# Altium schematic sheet AES-MS-MT3620-SK-G_FTDI.SchDoc(AES-MS-MT3620-SK-G_FTDI)
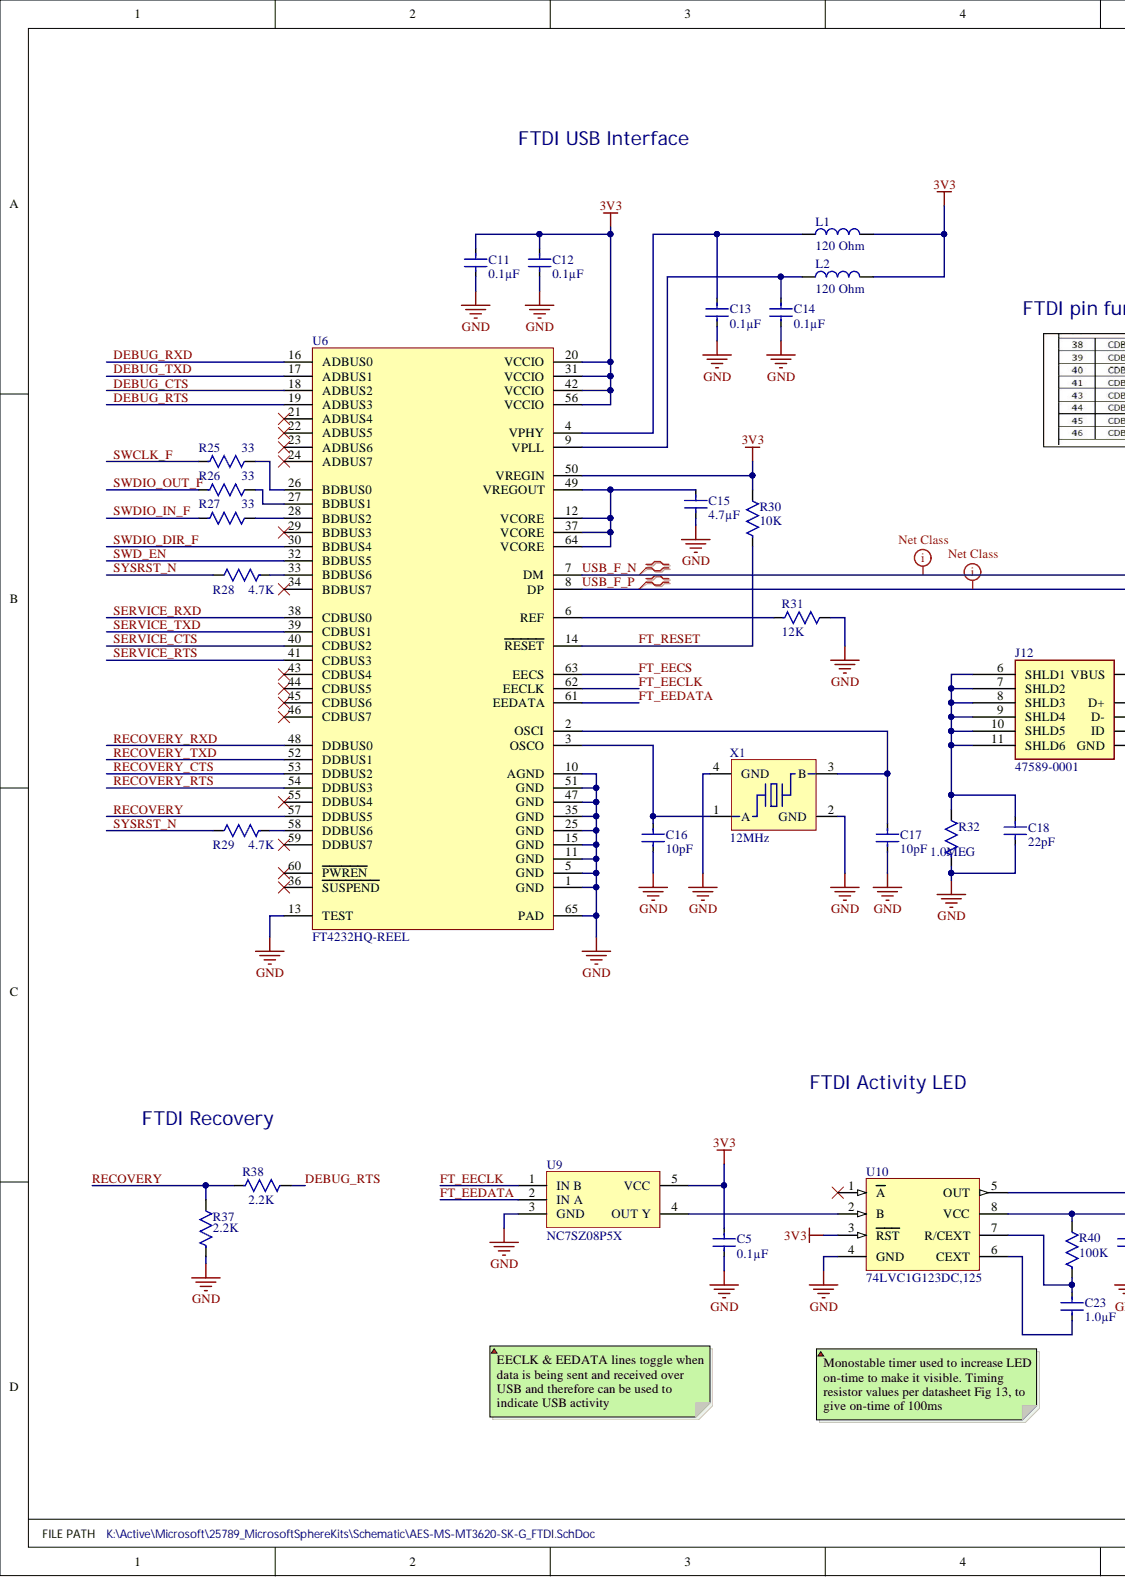
[diagram p0: AES-MS-MT3620-SK-G_FTDI.SchDoc, left]
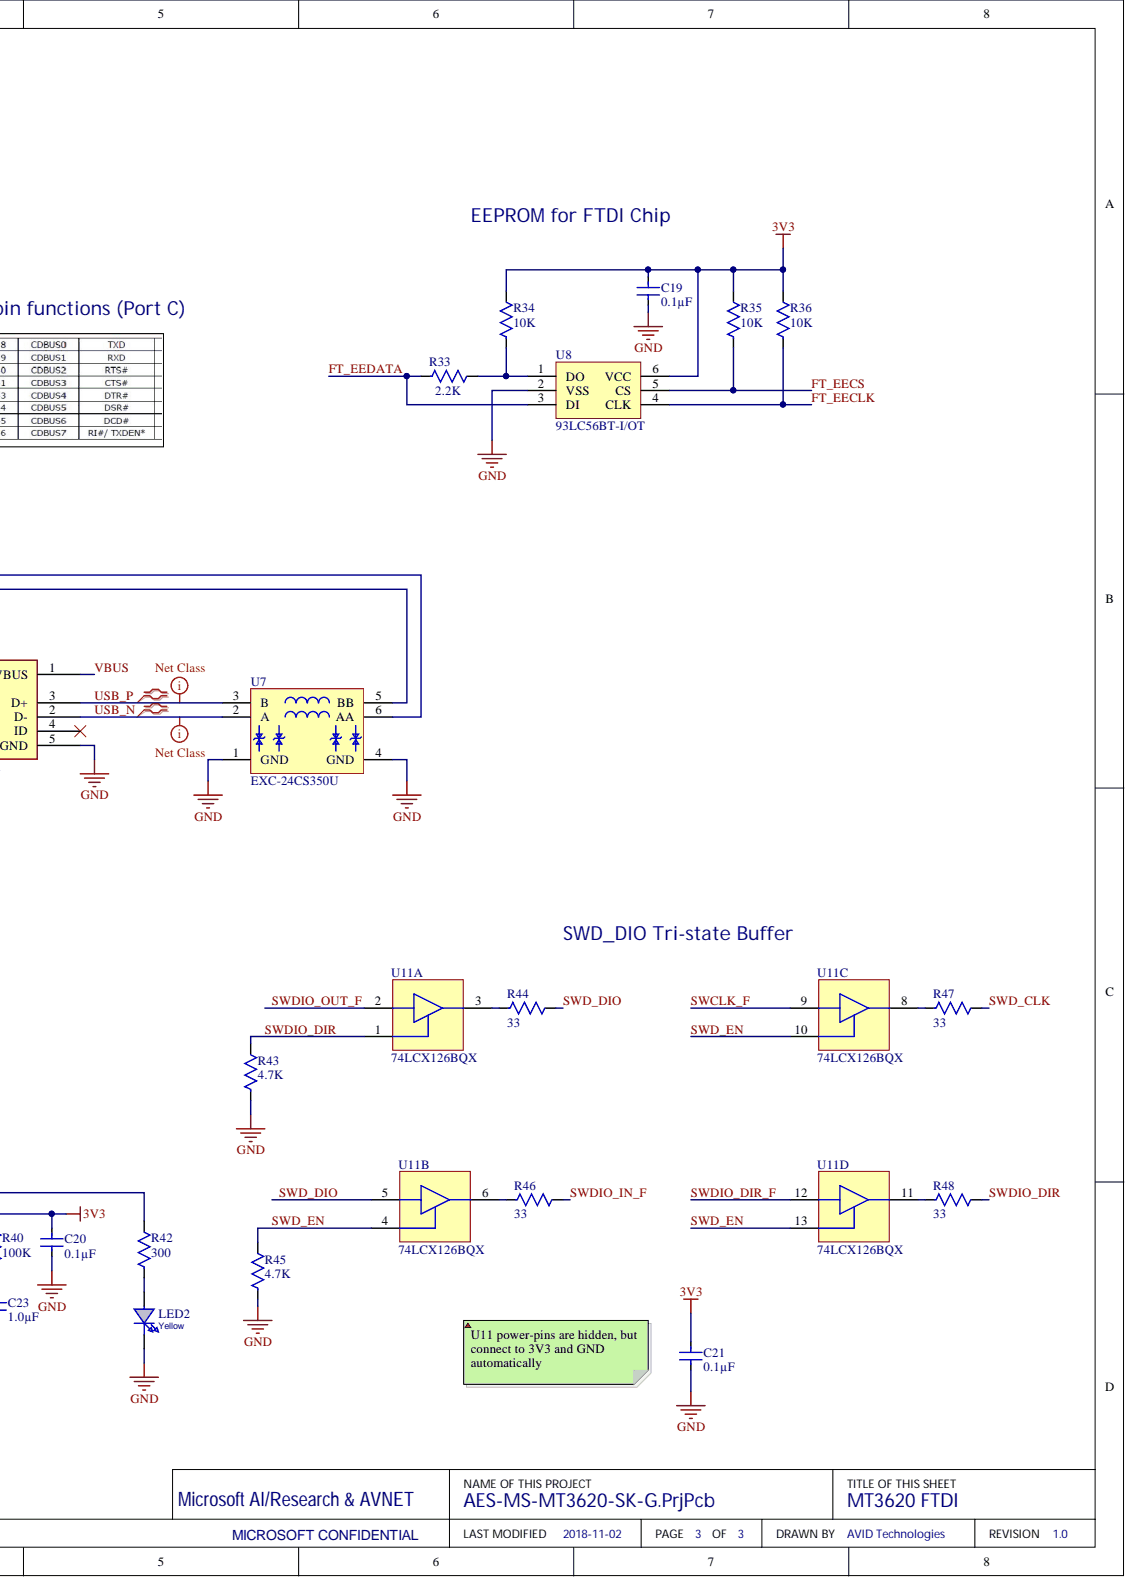
[diagram p1: AES-MS-MT3620-SK-G_FTDI.SchDoc, right]

## Parts
C5  Capacitance: 0.1µF  [CAPC1005-EIA0402 0.55MM MED]  (hidden comment: 0.1uF)
C11  Capacitance: 0.1µF  [CAPC1005-EIA0402 0.55MM MED]  (hidden comment: 0.1uF)
C12  Capacitance: 0.1µF  [CAPC1005-EIA0402 0.55MM MED]  (hidden comment: 0.1uF)
C13  Capacitance: 0.1µF  [CAPC1005-EIA0402 0.55MM MED]  (hidden comment: 0.1uF)
C14  Capacitance: 0.1µF  [CAPC1005-EIA0402 0.55MM MED]  (hidden comment: 0.1uF)
C15  Capacitance: 4.7µF  [CAPC1005-EIA0402 0.55MM MED]  (hidden comment: 4.7uF)
C16  10pF  [CAPC1005-EIA0402 0.55MM MED]
C17  10pF  [CAPC1005-EIA0402 0.55MM MED]
C18  22pF  [CAPC1005-EIA0402 0.55MM MED]
C19  Capacitance: 0.1µF  [CAPC1005-EIA0402 0.55MM MED]  (hidden comment: 0.1uF)
C20  Capacitance: 0.1µF  [CAPC1005-EIA0402 0.55MM MED]  (hidden comment: 0.1uF)
C21  Capacitance: 0.1µF  [CAPC1005-EIA0402 0.55MM MED]  (hidden comment: 0.1uF)
C23  1.0µF  [CAPC1005-EIA0402 0.55MM MED]
J12  47589-0001  [47589-0001]
L1  120 Ohm  [CAPC1005-EIA0402 0.55MM MED]
L2  120 Ohm  [CAPC1005-EIA0402 0.55MM MED]
LED2  Yellow
R25  33  [RES 0402 MEDIUM DENSITY]
R26  33  [RES 0402 MEDIUM DENSITY]
R27  33  [RES 0402 MEDIUM DENSITY]
R28  4.7K  [RES 0402 MEDIUM DENSITY]
R29  4.7K  [RES 0402 MEDIUM DENSITY]
R30  10K  [RES 0402 MEDIUM DENSITY]
R31  12K  [RES 0402 MEDIUM DENSITY]
R32  Resistance (Ohms): 1.0MEG  [RES 0402 MEDIUM DENSITY]  (hidden comment: 1MEG)
R33  2.2K  [RES 0402 MEDIUM DENSITY]
R34  10K  [RES 0402 MEDIUM DENSITY]
R35  10K  [RES 0402 MEDIUM DENSITY]
R36  10K  [RES 0402 MEDIUM DENSITY]
R37  2.2K  [RES 0402 MEDIUM DENSITY]
R38  2.2K  [RES 0402 MEDIUM DENSITY]
R40  100K  [RES 0402 MEDIUM DENSITY]
R42  300  [RES 0402 MEDIUM DENSITY]
R43  4.7K  [RES 0402 MEDIUM DENSITY]
R44  33  [RES 0402 MEDIUM DENSITY]
R45  4.7K  [RES 0402 MEDIUM DENSITY]
R46  33  [RES 0402 MEDIUM DENSITY]
R47  33  [RES 0402 MEDIUM DENSITY]
R48  33  [RES 0402 MEDIUM DENSITY]
U6  Manufacturer Part Number 1: FT4232HQ-REEL  [FTDI_TF4232HQ_64QFN]  (hidden comment: IC_FT4232HQ)
U7  Manufacturer Part Number 1: EXC-24CS350U  [EXC24CS]  (hidden comment: IC_EXC-24CS350U)
U8  Manufacturer Part Number 1: 93LC56BT-I/OT  [SOT23-93C56B]  (hidden comment: IC_93LC56BT-I/OT)
U9  Manufacturer Part Number 1: NC7SZ08P5X  [SC-70-5]  (hidden comment: IC_NC7SZ08P5X)
U10  Manufacturer Part Number 1: 74LVC1G123DC,125  [SOP50P310X100-8N]  (hidden comment: IC_74LVC1G123DC)
U11  IC_74LCX126BQX  [74LCX126BQX]  (value not drawn)  (parts A,B,C,D)
X1  12MHz  [Crystal_FL_NKS3]

## Nets
3V3: C5.2 C11.2 C12.2 C19.2 C20.2 C21.2 L1.2 L2.2 R30.1 R34.1 R35.1 R36.1 R40.1 U6.20(VCCIO) U6.31(VCCIO) U6.42(VCCIO) U6.50(VREGIN) U6.56(VCCIO) U8.6(VCC) U9.5(VCC) U10.3(RST) U10.8(VCC) U11.14
GND: C5.1 C11.1 C12.1 C13.1 C14.1 C15.1 C16.1 C17.1 C18.1 C19.1 C20.1 C21.1 J12.5(GND) LED2.K R31.1 R32.2 R37.2 R43.2 R45.2 U6.1(GND) U6.5(GND) U6.10(AGND) U6.11(GND) U6.13(TEST) U6.15(GND) U6.25(GND) U6.35(GND) U6.47(GND) U6.51(GND) U6.65(PAD) U7.1(GND) U7.4(GND) U8.2(VSS) U9.3(GND) U10.4(GND) U11.7 X1.2(GND) X1.4(GND)
DEBUG_CTS: U6.18(ADBUS2)
DEBUG_RTS: R38.1 U6.19(ADBUS3)
DEBUG_RXD: U6.16(ADBUS0)
DEBUG_TXD: U6.17(ADBUS1)
FT_EECLK: R36.2 U6.62(EECLK) U8.4(CLK) U9.1(IN_B)
FT_EECS: R35.2 U6.63(EECS) U8.5(CS)
FT_EEDATA: R33.2 U6.61(EEDATA) U8.3(DI) U9.2(IN_A)
FT_RESET: R30.2 U6.14(RESET)
RECOVERY: R37.1 R38.2 U6.57(DDBUS5)
RECOVERY_CTS: U6.53(DDBUS2)
RECOVERY_RTS: U6.54(DDBUS3)
RECOVERY_RXD: U6.48(DDBUS0)
RECOVERY_TXD: U6.52(DDBUS1)
SERVICE_CTS: U6.40(CDBUS2)
SERVICE_RTS: U6.41(CDBUS3)
SERVICE_RXD: U6.38(CDBUS0)
SERVICE_TXD: U6.39(CDBUS1)
SWCLK_F: R25.2 U11.9
SWD_CLK: R47.1
SWD_DIO: R44.1 U11.5
SWD_EN: R45.1 U6.32(BDBUS5) U11.4 U11.10 U11.13
SWDIO_DIR: R43.1 R48.1 U11.1
SWDIO_DIR_F: U6.30(BDBUS4) U11.12
SWDIO_IN_F: R27.2 R46.1
SWDIO_OUT_F: R26.2 U11.2
SYSRST_N: R28.1 R29.1
USB_F_N: U6.7(DM) U7.6(AA)
USB_F_P: U6.8(DP) U7.5(BB)
USB_N: J12.2(D-) U7.2(A)
USB_P: J12.3(D+) U7.3(B)
VBUS: J12.1(VBUS)
NetC13_2: C13.2 L1.1 U6.4(VPHY)
NetC14_2: C14.2 L2.1 U6.9(VPLL)
NetC15_2: C15.2 U6.12(VCORE) U6.37(VCORE) U6.49(VREGOUT) U6.64(VCORE)
NetC16_2: C16.2 U6.3(OSCO) X1.1(A)
NetC17_2: C17.2 U6.2(OSCI) X1.3(B)
NetC18_2: C18.2 J12.6(SHLD1) J12.7(SHLD2) J12.8(SHLD3) J12.9(SHLD4) J12.10(SHLD5) J12.11(SHLD6) R32.1
NetC23_1: C23.1 U10.6(CEXT)
NetC23_2: C23.2 R40.2 U10.7(R/CEXT)
NetLED2_A: LED2.A R42.2
NetR25_1: R25.1 U6.26(BDBUS0)
NetR26_1: R26.1 U6.27(BDBUS1)
NetR27_1: R27.1 U6.28(BDBUS2)
NetR28_2: R28.2 U6.33(BDBUS6)
NetR29_2: R29.2 U6.58(DDBUS6)
NetR31_2: R31.2 U6.6(REF)
NetR33_1: R33.1 R34.2 U8.1(DO)
NetR42_1: R42.1 U10.5(OUT)
NetR44_2: R44.2 U11.3
NetR46_2: R46.2 U11.6
NetR47_2: R47.2 U11.8
NetR48_2: R48.2 U11.11
NetU9_4: U9.4(OUT_Y) U10.2(B)

Not connected: J12 4(ID); U6 21(ADBUS4) 22(ADBUS5) 23(ADBUS6) 24(ADBUS7) 29(BDBUS3) 34(BDBUS7) 36(SUSPEND) 43(CDBUS4) 44(CDBUS5) 45(CDBUS6) 46(CDBUS7) 55(DDBUS4) 59(DDBUS7) 60(PWREN); U10 1(A)

## Library data of the parts
C5 C11-C14 C19-C21 = 0.1µF 0402 X7R 50V: CAP CER 0.1UF 25V 10% X5R 0402; Manufacturer Part Number 1: CL05A104KA5NNNC; Manufacturer 1: Samsung; Width: 0.02inch; Voltage Rating (DC): 25V; Voltage Rating: 25V; Voltage: 25V; Tolerance: 10%; Thickness: 0.022inch; Supplier Part Number 1: 1276-1043-1-ND; Supplier 1: Digi-Key; Stock 1: 100000; Radiation Hardening: No; Pricing 1: 1=0.1, 10=0.032, 100=0.0141, 500=0.0101, 1000=0.0079, 2500=0.0072, 5000=0.0066 (USD); Packaging: Tape and Reel; Package Quantity: 1; Mount: Surface Mount; Min Operating Temperature: -55°C; Max Operating Temperature: 85°C; Length: 1mm; Lead Free: Lead Free; Height: 0.5mm; Dielectric: X5R; Depth: 0.5mm; ComponentLink4URL: https://www.verical.com/server-webapp/html/getDatasheet?partID=357412; ComponentLink4Description: https://www.verical.com/server-webapp/html/getDatasheet?partID=357412; ComponentLink3URL: http://www1.futureelectronics.com/doc/SAMSUNG/CL05A104KA5NNNC.pdf; ComponentLink3Description: http://www1.futureelectronics.com/doc/SAMSUNG/CL05A104KA5NNNC.pdf; ComponentLink2URL: https://www.distrelec.biz/Web/Downloads/_e/ng/MLCC_CatalogDownload2013_ENG.pdf?mime=application%2Fpdf; ComponentLink2Description: https://www.distrelec.biz/Web/Downloads/_e/ng/MLCC_CatalogDownload2013_ENG.pdf?mime=application%2Fpdf; ComponentLink1URL: https://media.digikey.com/pdf/Data%20Sheets/Samsung%20PDFs/CL_Series_MLCC_ds.pdf; ComponentLink1Description: https://media.digikey.com/pdf/Data%20Sheets/Samsung%20PDFs/CL_Series_MLCC_ds.pdf; Component Kind: Standard; Case Code (Metric): 1005; Case Code (Imperial): 0402; Case/Package: 0402; Library Name: Sphere.SCHLIB
C15 = 4.7µF 0402 X5R 10V: CAP CER 4.7UF 10V 10% X5R 0402; Manufacturer Part Number 1: CL05A475KQ5NRNC; Manufacturer 1: Samsung; Voltage Rating: 6.3V; Width: 0.02inch; Tolerance: 10%; Thickness: 0.022inch; Supplier Part Number 1: 1276-1481-1-ND; Supplier 1: Digi-Key; Stock 1: 2; Radiation Hardening: No; Pricing 1: 1=0.45, 10=0.313, 100=0.1875, 500=0.1406, 1000=0.1188, 2500=0.1125, 5000=0.1063 (USD); Packaging: Tape and Reel; Package Quantity: 1; Mount: Surface Mount; Min Operating Temperature: -55°C; Max Operating Temperature: 85°C; Length: 1mm; Lead Free: Lead Free; Height: 0.5mm; Dielectric: X5R; Depth: 0.5mm; Contact Plating: Tin; ComponentLink2URL: https://www.verical.com/server-webapp/html/getDatasheet?partID=353228; ComponentLink2Description: https://www.verical.com/server-webapp/html/getDatasheet?partID=353228; ComponentLink1URL: http://www.samsungsem.com/kr/support/product-search/mlcc/CL05A475KQ5NRNC.jsp; ComponentLink1Description: http://www.samsungsem.com/kr/support/product-search/mlcc/CL05A475KQ5NRNC.jsp; Component Kind: Standard; Case Code (Metric): 1005; Case Code (Imperial): 0402; Case/Package: 0402; Library Name: Sphere.SCHLIB
C16 C17 = 10pF 0402 C0G, NP0 50V: CAP CER 10PF 50V NP0 0402; Manufacturer Part Number 1: C1005C0G1H100D050BA; Manufacturer 1: TDK Corporation; Tolerance: ±0.5pF; Voltage - Rated: 50V; Thickness (Max): 0.022" (0.55mm); Temperature Coefficient: C0G, NP0; Supplier Part Number 1: 445-1235-2-ND; Supplier 1: Digi-Key; Stock 1: 47833; Size / Dimension: 0.039" L x 0.020" W (1.00mm x 0.50mm); RoHS: RoHS Compliant; Ratings: -; Pricing 1: 0.00274; Packaging: Tape & Reel (TR); Package / Case: 0402 (1005 Metric); Operating Temperature: -55°C ~ 125°C; Mounting Type: Surface Mount, MLCC; Lead Style: -; Lead Spacing: -; Height - Seated (Max): -; Features: -; ComponentLink1URL: http://product.tdk.com/en/catalog/datasheets/mlcc_commercial_general_en.pdf; ComponentLink1Description: http://product.tdk.com/en/catalog/datasheets/mlcc_commercial_general_en.pdf; Component Kind: Standard; Category: Capacitors; Capacitance: 10pF; Applications: General Purpose; Library Name: Sphere.SCHLIB
C18 = 22pF 0402 C0G, NP0 50V: CAP CER 22PF 50V 5% NP0 0402; Manufacturer Part Number 1: C1005C0G1H220J050BA; Manufacturer 1: TDK; Temperature Coefficient: 30ppm/°C; Insulation Resistance: 10GR; Dielectric Material: Ceramic; Dielectric: C0G; Width: 0.5mm; Weight: 2.3E-05oz; Voltage Rating (DC): 50V; Voltage Rating: 50V; Voltage: 50V; Tolerance: 5%; Thickness: 0.022inch; Termination: SMD/SMT; Supplier Part Number 1: 445-1239-1-ND; Supplier 1: Digi-Key; Stock 1: 48493; REACH SVHC: No SVHC; Radiation Hardening: No; Pricing 1: 1=0.1, 10=0.051, 100=0.0225, 500=0.0161, 1000=0.0127, 2500=0.0115, 5000=0.0106 (USD); Packaging: Tape and Reel; Package Quantity: 1; Mount: Surface Mount; Min Operating Temperature: -55°C; Max Operating Temperature: 125°C; Length: 1mm; Lead Free: Lead Free; Height: 0.5mm; Depth: 0.5mm; Component Kind: Standard; Case Code (Metric): 1005; Case Code (Imperial): 0402; Case/Package: 0402; Capacitance: 22pF; Library Name: Sphere.SCHLIB
C23 = 1.0µF 0402 X5R 35V: CAP CER 1UF 35V 10% X5R 0402; Manufacturer Part Number 1: C1005X5R1V105K050BC; Manufacturer 1: TDK Corporation; Voltage - Rated: 35V; Tolerance: ±10%; Thickness (Max): 0.022" (0.55mm); Temperature Coefficient: X5R; Supplier Part Number 1: 445-9073-2-ND; Supplier 1: Digi-Key; Stock 1: 47833; Size / Dimension: 0.039" L x 0.020" W (1.00mm x 0.50mm); RoHS: RoHS Compliant; Ratings: -; Pricing 1: 0.0186; Packaging: Tape & Reel (TR); Package / Case: 0402 (1005 Metric); Operating Temperature: -55°C ~ 125°C; Mounting Type: Surface Mount, MLCC; Lead Style: -; Lead Spacing: -; Height - Seated (Max): -; Features: -; ComponentLink1URL: http://product.tdk.com/en/catalog/datasheets/mlcc_commercial_general_en.pdf; ComponentLink1Description: http://product.tdk.com/en/catalog/datasheets/mlcc_commercial_general_en.pdf; Component Kind: Standard; Category: Capacitors; Capacitance: 1.0µF; Applications: General Purpose; Library Name: Sphere.SCHLIB
J12 = CON_USB_Mini_AB_47589-0001: CONN RCPT MICRO USB AB R/A SMD; Manufacturer 1: Molex; Voltage Rating (AC): 30V; USB Standard: USB 2.0; Pitch: 0.65mm; Number of Ports: 1; Number of Contacts: 5; Max Voltage Rating (AC): 100V; Gender: Female; Current Rating: 1.8A; Contact Resistance: 40mR; Connector Type: Receptacle,USB; Width: 0.25inch; Weight: 0.01lb; Voltage Rating: 30V; Termination: Solder; Supplier Part Number 1: WM17143CT-ND; Supplier 1: Digi-Key; Stock 1: 70197; REACH SVHC: Unknown; Radiation Hardening: No; Pricing 1: 1=0.74, 10=0.649, 25=0.6108, 50=0.5854, 100=0.56, 250=0.5091, 500=0.4582 (USD); Packaging: Tape and Reel; Orientation: Right Angle; Number of Terminals: 5; Mount: PCB,Surface Mount; Min Operating Temperature: -20°C; Max Operating Temperature: 85°C; Manufacturer Part Number 1: 47589-0001; Length: 8.65mm; Housing Material: Polymer; Height: 0.25inch; Contact Plating: Gold; Contact Material: Copper,Copper Alloy; Component Kind: Standard; Colour: Black; Library Name: Sphere.SCHLIB
L1 L2 = FB 120 0402: FERRITE BEAD 120 OHM 0402 1LN; Manufacturer Part Number 1: BLM15PD121SN1D; Manufacturer 1: Murata; Tolerance: 25%; Test Frequency: 100MHz; Number of Lines: 1; Max DC Current: 1.3A; Material: Ferrite,Steel; Impedance: 120R; DC Resistance (DCR): 90mR; Width: 0.5mm; Termination: SMD/SMT; Supplier Part Number 1: 490-5203-1-ND; Supplier 1: Digi-Key; Stock 1: 471321; REACH SVHC: No SVHC; Radiation Hardening: No; Pricing 1: 1=0.12, 10=0.096, 25=0.0868, 50=0.0636, 100=0.0549, 250=0.0433, 500=0.0366, 1000=0.0308, 5000=0.0274 (USD); Packaging: Tape and Reel; Package Quantity: 1; Number of Pins: 2; Number of Circuits: 1; Min Operating Temperature: -55°C; Military Standard: Not; Max Operating Temperature: 125°C; Max Current Rating: 1.3A; Length: 1mm; Lead Free: Lead Free; Height: 0.5mm; Depth: 0.55mm; DC Current: 1.3A; Current Rating: 1.3A; Core Material: Ferrite; ComponentLink6URL: http://www.produktinfo.conrad.com/datenblaetter/1100000-1199999/001172205-da-01-en-DROSSEL_0402_120R_11_0X0_5MM_10000ST.pdf; ComponentLink6Description: http://www.produktinfo.conrad.com/datenblaetter/1100000-1199999/001172205-da-01-en-DROSSEL_0402_120R_11_0X0_5MM_10000ST.pdf; ComponentLink5URL: http://www.produktinfo.conrad.com/datenblaetter/1100000-1199999/001171686-da-01-en-CHIP_DROSSEL0402_120R_1300MA_1_0X0_5MM.pdf; ComponentLink5Description: http://www.produktinfo.conrad.com/datenblaetter/1100000-1199999/001171686-da-01-en-CHIP_DROSSEL0402_120R_1300MA_1_0X0_5MM.pdf; ComponentLink4URL: http://uk.rs-online.com/webdocs/1424/0900766b81424caa.pdf; ComponentLink4Description: http://uk.rs-online.com/webdocs/1424/0900766b81424caa.pdf; ComponentLink3URL: https://www.verical.com/server-webapp/html/getDatasheet?partID=195858; ComponentLink3Description: https://www.verical.com/server-webapp/html/getDatasheet?partID=195858; ComponentLink2URL: http://www.murata.com/en-global/products/productdata/8796733767710/S0580E.pdf; ComponentLink2Description: http://www.murata.com/en-global/products/productdata/8796733767710/S0580E.pdf; ComponentLink1URL: https://www.murata.com/en-us/products/productdata/8796740059166/ENFA0018.pdf; ComponentLink1Description: https://www.murata.com/en-us/products/productdata/8796740059166/ENFA0018.pdf; Component Kind: Standard; Case Code (Metric): 1005; Case Code (Imperial): 0402; Case/Package: 0402; Library Name: Sphere.SCHLIB
LED2 = LED_1608_YELLOW_LTST: LED GREEN 26MCD 0603 SMD; Manufacturer Part Number 1: LTST-C193KSKT-5A; Manufacturer 1: Vishay Lite-On; Viewing Angle: 130°; Test Current: 5mA; Reverse Voltage: 5V; Power Dissipation: 75mW; Number of LEDs: 1; Lens Transparency: Clear; Lens Style: Colorless,Diffused,Rectangular; Lens Colour: Clear,Colorless; Forward Voltage: 2.3V; Forward Current: 30mA; Dominant Wavelength: 594.5nm; Width: 0.8mm; Supplier Part Number 1: 160-1831-1-ND; Supplier 1: Digi-Key; Stock 1: 120962; Radiation Hardening: No; Pricing 1: 1=0.41, 10=0.296, 25=0.2268, 100=0.148, 250=0.1085, 500=0.0921, 1000=0.0757, 2500=0.0658 (USD); Packaging: Tape and Reel; Package Quantity: 1; Number of Pins: 2; Number of Elements: 1; Mount: Surface Mount; Min Operating Temperature: -55°C; Max Operating Temperature: 85°C; Luminous Intensity: 26mcd; Length: 1.6mm; Lead Free: Lead Free; Illumination Colour: Yellow; Height: 0.35mm; Depth: 0.8mm; ComponentLink3URL: http://www.mouser.com/ds/2/239/Lite-On-LTST-C193KSKT-5A-187434.pdf; ComponentLink3Description: http://www.mouser.com/ds/2/239/Lite-On-LTST-C193KSKT-5A-187434.pdf; ComponentLink2URL: https://www.verical.com/server-webapp/html/getDatasheet?partID=683868; ComponentLink2Description: https://www.verical.com/server-webapp/html/getDatasheet?partID=683868; ComponentLink1URL: http://optoelectronics.liteon.com/upload/download/DS22-2004-141/LTST-C193KSKT-5A.PDF; ComponentLink1Description: http://optoelectronics.liteon.com/upload/download/DS22-2004-141/LTST-C193KSKT-5A.PDF; Component Kind: Standard; Colour: Yellow; Case Code (Metric): 1608; Case Code (Imperial): 0603; Case/Package: 0603; Library Name: Sphere.SCHLIB
R25-R27 R44 R46-R48 = 33 0402: RES 33 OHM 1/10W 1% 0402 SMD; Manufacturer Part Number 1: ERJ-2RKF33R0X; Manufacturer 1: Panasonic Electronic Components; Temperature Coefficient: ±100ppm/°C; Power (Watts): 0.1W, 1/10W; Tolerance: ±1%; Supplier Part Number 1: P33.0LCT-ND; Supplier Device Package: 0402; Supplier 1: Digi-Key; Stock 1: 533394; Size / Dimension: 0.039" L x 0.020" W (1.00mm x 0.50mm); RoHS: RoHS Compliant; Resistance (Ohms): 33; Pricing 1: 1=0.07, 50=0.0128, 100=0.0097, 250=0.0072, 500=0.00532, 1000=0.00355, 5000=0.0025 (GBP); Packaging: Cut Tape (CT); Package / Case: 0402 (1005 Metric); Number of Terminations: 2; Height: 0.016" (0.40mm); Features: -; Composition: Thick Film; ComponentLink1URL: http://industrial.panasonic.com/www-cgi/jvcr13pz.cgi?E+PZ+3+AOA0002+ERJ2RKF33R0X+7+WW; ComponentLink1Description: http://industrial.panasonic.com/www-cgi/jvcr13pz.cgi?E+PZ+3+AOA0002+ERJ2RKF33R0X+7+WW; Component Kind: Standard; Category: Resistors; Library Name: Sphere.SCHLIB
R28 R29 R43 R45 = 4.7K 0402: RES 4.7K OHM 1/10W 1% 0402 SMD; Manufacturer Part Number 1: ERJ-2RKF4701X; Manufacturer 1: Panasonic Electronic Components; Temperature Coefficient: ±100ppm/°C; Power (Watts): 0.1W, 1/10W; Tolerance: ±1%; Supplier Part Number 1: P4.70KLCT-ND; Supplier Device Package: 0402; Supplier 1: Digi-Key; Stock 1: 1251398; Size / Dimension: 0.039" L x 0.020" W (1.00mm x 0.50mm); RoHS: RoHS Compliant; Resistance (Ohms): 4.7K; Pricing 1: 1=0.07, 50=0.0128, 100=0.0097, 250=0.0072, 500=0.00532, 1000=0.00355, 5000=0.0025 (GBP); Packaging: Cut Tape (CT); Package / Case: 0402 (1005 Metric); Number of Terminations: 2; Height: 0.016" (0.40mm); Features: -; Composition: Thick Film; ComponentLink1URL: http://industrial.panasonic.com/www-cgi/jvcr13pz.cgi?E+PZ+3+AOA0002+ERJ2RKF4701X+7+WW; ComponentLink1Description: http://industrial.panasonic.com/www-cgi/jvcr13pz.cgi?E+PZ+3+AOA0002+ERJ2RKF4701X+7+WW; Component Kind: Standard; Category: Resistors; Library Name: Sphere.SCHLIB
R30 R34-R36 = 10K 0402: RES 10K OHM 1/10W 1% 0402 SMD; Manufacturer Part Number 1: ERJ-2RKF1002X; Manufacturer 1: Panasonic Electronic Components; Temperature Coefficient: ±100ppm/°C; Power (Watts): 0.1W, 1/10W; Tolerance: ±1%; Supplier Part Number 1: P10.0KLCT-ND; Supplier Device Package: 0402; Supplier 1: Digi-Key; Stock 1: 4805447; Size / Dimension: 0.039" L x 0.020" W (1.00mm x 0.50mm); RoHS: RoHS Compliant; Resistance (Ohms): 10K; Pricing 1: 1=0.07, 50=0.0128, 100=0.0097, 250=0.0072, 500=0.00532, 1000=0.00355, 5000=0.0025 (GBP); Packaging: Cut Tape (CT); Package / Case: 0402 (1005 Metric); Number of Terminations: 2; Height: 0.016" (0.40mm); Features: -; Composition: Thick Film; ComponentLink1URL: http://industrial.panasonic.com/www-cgi/jvcr13pz.cgi?E+PZ+3+AOA0002+ERJ2RKF1002X+7+WW; ComponentLink1Description: http://industrial.panasonic.com/www-cgi/jvcr13pz.cgi?E+PZ+3+AOA0002+ERJ2RKF1002X+7+WW; Component Kind: Standard; Category: Resistors; Library Name: Sphere.SCHLIB
R31 = 12K 0402: RES 12K OHM 1/10W 1% 0402 SMD; Manufacturer Part Number 1: ERJ-2RKF1202X; Manufacturer 1: Panasonic; Voltage Rating (DC): 50V; Temperature Coefficient: 100ppm/°C; Power Rating: 100mW; Width: 0.02inch; Voltage Rating: 50V; Tolerance: 1%; Termination: SMD/SMT; Supplier Part Number 1: P12.0KLCT-ND; Supplier 1: Digi-Key; Stock 1: 1117646; Resistance (Ohms): 12K; REACH SVHC: No SVHC; Pricing 1: 1=0.1, 10=0.045, 100=0.0184, 1000=0.0083, 2500=0.0072, 5000=0.0059 (USD); Packaging: Tape and Reel; Package Quantity: 1; Number of Terminations: 2; Number of Pins: 2; Mount: Surface Mount; Min Operating Temperature: -55°C; Military Standard: Not; Max Power Dissipation: 100mW; Max Operating Temperature: 155°C; Length: 1mm; Lead Free: Lead Free; Height: 0.35mm; Features: Automotive AEC-Q200; Depth: 0.5mm; Contact Plating: Tin; Composition: Thick Film; ComponentLink3URL: http://www.farnell.com/datasheets/1678782.pdf; ComponentLink3Description: http://www.farnell.com/datasheets/1678782.pdf; ComponentLink2URL: http://www.farnell.com/datasheets/426256.pdf; ComponentLink2Description: http://www.farnell.com/datasheets/426256.pdf; ComponentLink1URL: http://industrial.panasonic.com/www-data/pdf/AOA0000/AOA0000CE2.pdf; ComponentLink1Description: http://industrial.panasonic.com/www-data/pdf/AOA0000/AOA0000CE2.pdf; Component Kind: Standard; Case Code (Metric): 1005; Case Code (Imperial): 0402; Case/Package: 0402; Library Name: Sphere.SCHLIB
R32 = 1.0MEG 0402: RES 1M OHM 1/10W 1% 0402 SMD; Manufacturer Part Number 1: ERJ-2RKF1004X; Manufacturer 1: Panasonic; Working Voltage: 50V; Temperature Coefficient: 100ppm/°C; Power Rating: 100mW; Width: 0.02inch; Weight: 2.8E-05oz; Voltage Rating (DC): 50V; Voltage Rating: 50V; Tolerance: 1%; Termination: SMD/SMT; Supplier Part Number 1: P1.00MLTR-ND; Supplier 1: Digi-Key; Stock 1: 2820000; REACH SVHC: No SVHC; Radiation Hardening: No; Pricing 1: 10000=0.0053, 30000=0.0047, 50000=0.0044, 100000=0.0043 (USD); Packaging: Tape and Reel; Package Quantity: 1; Number of Terminations: 2; Number of Pins: 2; Mount: Surface Mount; Min Operating Temperature: -55°C; Military Standard: Not; Max Power Dissipation: 100mW; Max Operating Temperature: 155°C; Length: 1mm; Lead Free: Lead Free; Height: 0.35mm; Features: Automotive AEC-Q200; Depth: 0.5mm; Contact Plating: Tin; Composition: Thick Film; ComponentLink7URL: http://www.jameco.com/Jameco/Products/ProdDS/1987046.pdf; ComponentLink7Description: http://www.jameco.com/Jameco/Products/ProdDS/1987046.pdf; ComponentLink6URL: http://www.farnell.com/datasheets/1911175.pdf; ComponentLink6Description: http://www.farnell.com/datasheets/1911175.pdf; ComponentLink5URL: http://www.mouser.com/ds/2/315/AOA0000C86-911473.pdf; ComponentLink5Description: http://www.mouser.com/ds/2/315/AOA0000C86-911473.pdf; ComponentLink4URL: http://industrial.panasonic.com/www-data/pdf/AOA0000/AOA0000CE2.pdf; ComponentLink4Description: http://industrial.panasonic.com/www-data/pdf/AOA0000/AOA0000CE2.pdf; ComponentLink3URL: https://industrial.panasonic.com/cdbs/www-data/pdf/RDA0000/AOA0000C304.pdf; ComponentLink3Description: https://industrial.panasonic.com/cdbs/www-data/pdf/RDA0000/AOA0000C304.pdf; ComponentLink2URL: http://www.alliedelec.com/m/d/623f45ff1957d936ff56d46fd1c28cac.pdf; ComponentLink2Description: http://www.alliedelec.com/m/d/623f45ff1957d936ff56d46fd1c28cac.pdf; ComponentLink1URL: http://www.farnell.com/datasheets/1678782.pdf; ComponentLink1Description: http://www.farnell.com/datasheets/1678782.pdf; Component Kind: Standard; Case Code (Metric): 1005; Case Code (Imperial): 0402; Case/Package: 0402; Library Name: Sphere.SCHLIB
R33 R37 R38 = 2.2K 0402: RES 2.2K OHM 1/10W 1% 0402 SMD; Manufacturer Part Number 1: ERJ-2RKF2201X; Manufacturer 1: Panasonic; Voltage Rating (DC): 50V; Temperature Coefficient: 100ppm/°C; Power Rating: 100mW; Width: 0.5mm; Weight: 2.8E-05oz; Voltage Rating: 50V; Tolerance: 1%; Termination: SMD/SMT; Supplier Part Number 1: P2.20KLCT-ND; Supplier 1: Digi-Key; Stock 1: 7339314; Resistance (Ohms): 2.2K; REACH SVHC: No SVHC; Radiation Hardening: No; Pricing 1: 1=0.1, 10=0.045, 100=0.0184, 1000=0.0083, 2500=0.0072, 5000=0.0059 (USD); Packaging: Tape and Reel; Package Quantity: 1; Number of Terminations: 2; Number of Pins: 2; Mount: Surface Mount; Min Operating Temperature: -55°C; Military Standard: Not; Max Power Dissipation: 100mW; Max Operating Temperature: 155°C; Length: 1mm; Lead Free: Lead Free; Height: 0.35mm; Features: Automotive AEC-Q200; Depth: 0.5mm; Contact Plating: Tin; Composition: Thick Film; ComponentLink4URL: http://www.farnell.com/datasheets/1911175.pdf; ComponentLink4Description: http://www.farnell.com/datasheets/1911175.pdf; ComponentLink3URL: http://www.farnell.com/datasheets/1678782.pdf; ComponentLink3Description: http://www.farnell.com/datasheets/1678782.pdf; ComponentLink2URL: https://www.alliedelec.com/m/d/623f45ff1957d936ff56d46fd1c28cac.pdf; ComponentLink2Description: https://www.alliedelec.com/m/d/623f45ff1957d936ff56d46fd1c28cac.pdf; ComponentLink1URL: http://industrial.panasonic.com/www-data/pdf/AOA0000/AOA0000CE2.pdf; ComponentLink1Description: http://industrial.panasonic.com/www-data/pdf/AOA0000/AOA0000CE2.pdf; Component Kind: Standard; Case Code (Metric): 1005; Case Code (Imperial): 0402; Case/Package: 0402; Library Name: Sphere.SCHLIB
R40 = 100K 0402: RES 100K OHM 1/10W 1% 0402 SMD; Manufacturer Part Number 1: ERJ-2RKF1003X; Manufacturer 1: Panasonic Electronic Components; Temperature Coefficient: ±100ppm/°C; Power (Watts): 0.1W, 1/10W; Tolerance: ±1%; Supplier Part Number 1: P100KLCT-ND; Supplier Device Package: 0402; Supplier 1: Digi-Key; Stock 1: 2310913; Size / Dimension: 0.039" L x 0.020" W (1.00mm x 0.50mm); RoHS: RoHS Compliant; Resistance (Ohms): 100K; Pricing 1: 1=0.07, 50=0.0128, 100=0.0097, 250=0.0072, 500=0.00532, 1000=0.00355, 5000=0.0025 (GBP); Packaging: Cut Tape (CT); Package / Case: 0402 (1005 Metric); Number of Terminations: 2; Height: 0.016" (0.40mm); Features: -; Composition: Thick Film; ComponentLink1URL: http://industrial.panasonic.com/www-cgi/jvcr13pz.cgi?E+PZ+3+AOA0002+ERJ2RKF1003X+7+WW; ComponentLink1Description: http://industrial.panasonic.com/www-cgi/jvcr13pz.cgi?E+PZ+3+AOA0002+ERJ2RKF1003X+7+WW; Component Kind: Standard; Category: Resistors; Library Name: Sphere.SCHLIB
R42 = 300 0402: RES 300 OHM 1/10W 1% 0402 SMD; Manufacturer Part Number 1: ERJ-2RKF3000X; Manufacturer 1: Panasonic Electronic Components; Temperature Coefficient: ±100ppm/°C; Power (Watts): 0.1W, 1/10W; Tolerance: ±1%; Supplier Part Number 2: 2302577; Supplier Part Number 1: P300LCT-ND; Supplier Device Package: 0402; Supplier 2: Farnell; Supplier 1: Digi-Key; Stock 1: 55335; Size / Dimension: 0.039" L x 0.020" W (1.00mm x 0.50mm); RoHS: RoHS Compliant; Resistance (Ohms): 300; Pricing 1: 1=0.07, 50=0.0128, 100=0.0097, 250=0.0072, 500=0.00532, 1000=0.00355, 5000=0.0025 (GBP); Packaging: Cut Tape (CT); Package / Case: 0402 (1005 Metric); Number of Terminations: 2; Height: 0.016" (0.40mm); Features: -; Composition: Thick Film; ComponentLink1URL: http://industrial.panasonic.com/www-cgi/jvcr13pz.cgi?E+PZ+3+AOA0002+ERJ2RKF3000X+7+WW; ComponentLink1Description: http://industrial.panasonic.com/www-cgi/jvcr13pz.cgi?E+PZ+3+AOA0002+ERJ2RKF3000X+7+WW; Component Kind: Standard; Category: Resistors; Library Name: Sphere.SCHLIB
U6 = IC_FT4232HQ: IC USB HS QUAD UART/SYNC 64-QFN; Manufacturer 1: FTDI; Operating Supply Voltage: 3.3V; Operating Supply Current: 70mA; Number of Transceivers: 4; Number of Channels: 1; Min Supply Voltage: 1.62V; Max Supply Voltage: 3.63V; Interface: UART; ESD Protection: Yes; Data Rate: 480Mbps; Width: 9mm; Weight: 0.007277oz; Supplier Part Number 1: 768-1027-1-ND; Supplier 1: Digi-Key; Stock 1: 49857; Pricing 1: 1=8.75, 10=8.45, 100=6.84, 250=6.09, 500=5.55, 1000=5.0985 (USD); Packaging: Tape and Reel; Package Quantity: 4000; Number of Pins: 64; Mount: Surface Mount; Min Operating Temperature: -40°C; Max Operating Temperature: 85°C; Length: 9mm; Lead Free: Lead Free; Height: 0.85mm; Dual Supply Voltage: 3.3V; Component Kind: Standard; Case/Package: QFN; Library Name: Sphere.SCHLIB
U7 = IC_EXC-24CS350U: IC FILTER CMC 100MA 2LN 35 OHM SMD ESD; Manufacturer 1: Panasonic; Voltage Rating (DC): 5V; Number of Lines: 2; DC Resistance (DCR): 2R; Width: 0.039inch; Supplier Part Number 1: P19423CT-ND; Supplier 1: Digi-Key; Stock 1: 5000; Pricing 1: 1=0.23, 10=0.211, 100=0.1404, 500=0.117, 1000=0.0983, 2500=0.0843 (USD); Packaging: Tape and Reel; Mount: Surface Mount; Min Operating Temperature: -40°C; Max Operating Temperature: 85°C; Max Current Rating: 100mA; Length: 0.049inch; Height: 0.024inch; Component Kind: Standard; Case Code (Metric): 1308; Case Code (Imperial): 0503; Case/Package: 0503; Library Name: Sphere.SCHLIB
U8 = IC_93LC56BT-I/OT: IC EEPROM 2KBIT 2MHZ SOT23-6; Manufacturer 1: Microchip; Nominal Supply Current: 2mA; Min Supply Voltage: 2.5V; Memory Size: 2Kb; Max Supply Voltage: 5.5V; Interface: Serial; Access Time: 250ns; Width: 1.8mm; Supplier Part Number 1: 93LC56BT-I/OTCT-ND; Supplier 1: Digi-Key; Stock 1: 24507; REACH SVHC: No SVHC; Radiation Hardening: No; Pricing 1: 1=0.23, 25=0.2164, 100=0.2119 (USD); Packaging: Tape and Reel; Package Quantity: 3000; Number of Pins: 6; Mount: Surface Mount; Min Operating Temperature: -40°C; Memory Type: EEPROM; Max Operating Temperature: 85°C; Max Frequency: 2MHz; Length: 3.1mm; Lead Free: Lead Free; Height: 1.3mm; Frequency: 2MHz; Density: 2Kb; Contact Plating: Matte,Tin; Component Kind: Standard; Case/Package: SOT-23; Library Name: Sphere.SCHLIB
U9 = IC_NC7SZ08P5X: IC GATE AND 1CH 2-INP SC-70-5; Manufacturer 1: ON Semiconductor / Fairchild; Turn-On Delay Time: 5.2ns; Quiescent Current: 2uA; Propagation Delay: 11ns; Output Current: 32mA; Number of Outputs: 1; Number of Inputs: 2; Min Supply Voltage: 1.65V; Max Supply Voltage: 5.5V; High Level Output Current: -32mA; Weight: 23g; Supplier Part Number 1: NC7SZ08P5XCT-ND; Supplier 1: Digi-Key; Stock 1: 77803; REACH SVHC: No SVHC; Radiation Hardening: No; Pricing 1: 1=0.36, 10=0.269, 100=0.1526, 500=0.101, 1000=0.0775 (USD); Packaging: Tape and Reel; Package Quantity: 1; Number of Pins: 5; Number of Gates: 1; Number of Elements: 1; Number of Circuits: 1; Number of Channels: 2; Mount: Surface Mount; Min Operating Temperature: -40°C; Max Operating Temperature: 85°C; Low Level Output Current: 32mA; Logic Function: AND; Lead Free: Lead Free; Contact Plating: Matte,Tin; Component Kind: Standard; Case/Package: SC; Library Name: Sphere.SCHLIB
U10 = IC_74LVC1G123DC: IC MULTIVIBRATOR MONO 8VSSOP; Manufacturer 1: NXP Semiconductors; Schmitt Trigger Input: Yes; Quiescent Current: 20uA; Propagation Delay: 5.3ns; Output Current: 50mA; Number of Elements: 1; Min Supply Voltage: 1.65V; Max Supply Voltage: 5.5V; Low Level Output Current: 32mA; High Level Output Current: -32mA; Supplier Part Number 1: 1727-2066-1-ND; Supplier 1: Digi-Key; Stock 1: 3503; REACH SVHC: No SVHC; Pricing 1: 1=0.54, 10=0.464, 100=0.3461, 500=0.2719, 1000=0.2101 (USD); Packaging: Tape and Reel; Package Quantity: 3000; Number of Pins: 8; Mount: Surface Mount; Min Operating Temperature: -40°C; Max Operating Temperature: 125°C; Logic Function: Multivibrator; Lead Free: Lead Free; Independent Circuits: 1; Component Kind: Standard; Case/Package: VSSOP; Library Name: Sphere.SCHLIB
U11 = IC_74LCX126BQX: IC BUF NON-INVERT 3.6V 14DQFN; Manufacturer 1: ON Semiconductor / Fairchild; Turn-On Delay Time: 7.8ns; Quiescent Current: 10uA; Polarity: Non-Inverting; Number of Outputs: 4; Number of Bits per Element: 1; Min Supply Voltage: 2V; Low Level Output Current: 24mA; Logic Function: Buffer,Inverting; High Level Output Current: -24mA; Weight: 0.12g; Supplier Part Number 1: 74LCX126BQXCT-ND; Supplier 1: Digi-Key; Stock 1: 9121; Radiation Hardening: No; Propagation Delay: 7.8ns; Pricing 1: 1=0.85, 10=0.744, 100=0.5702, 500=0.4507, 1000=0.3606 (USD); Packaging: Tape and Reel; Package Quantity: 3000; Number of Pins: 14; Number of Output Lines: 4; Number of Inputs: 4; Number of Input Lines: 4; Number of Elements: 4; Number of Channels: 4; Number of Bits: 4; Mount: Surface Mount; Min Operating Temperature: -40°C; Max Supply Voltage: 3.6V; Max Operating Temperature: 85°C; Manufacturer Part Number 1: 74LCX126BQX; Lead Free: Lead Free; Contact Plating: Gold; Component Kind: Standard; Library Name: Sphere.SCHLIB
X1 = CRYSTAL 12MHz 3.2x2.5: CRYSTAL 12MHZ 18PF SMD; Manufacturer Part Number 1: FL1200112; Manufacturer 1: Diodes; Frequency Tolerance: 30ppm; ESR (Equivalent Series Resistance): 80R; Width: 0.098inch; Supplier Part Number 1: FL1200112TR-ND; Supplier 1: Digi-Key; Stock 1: 0; Packaging: Tape and Reel; Mount: Surface Mount; Min Operating Temperature: -40°C; Max Operating Temperature: 85°C; Load Capacitance: 18pF; Length: 0.126inch; Height: 0.03inch; Frequency Stability: 30ppm; Frequency: 12MHz; Component Kind: Standard; Case/Package: SMD/SMT; Library Name: Sphere.SCHLIB
